# Altium schematic sheet BW1098FFC_TopLevel.SchDoc(BW1098FFC_TopLevel)
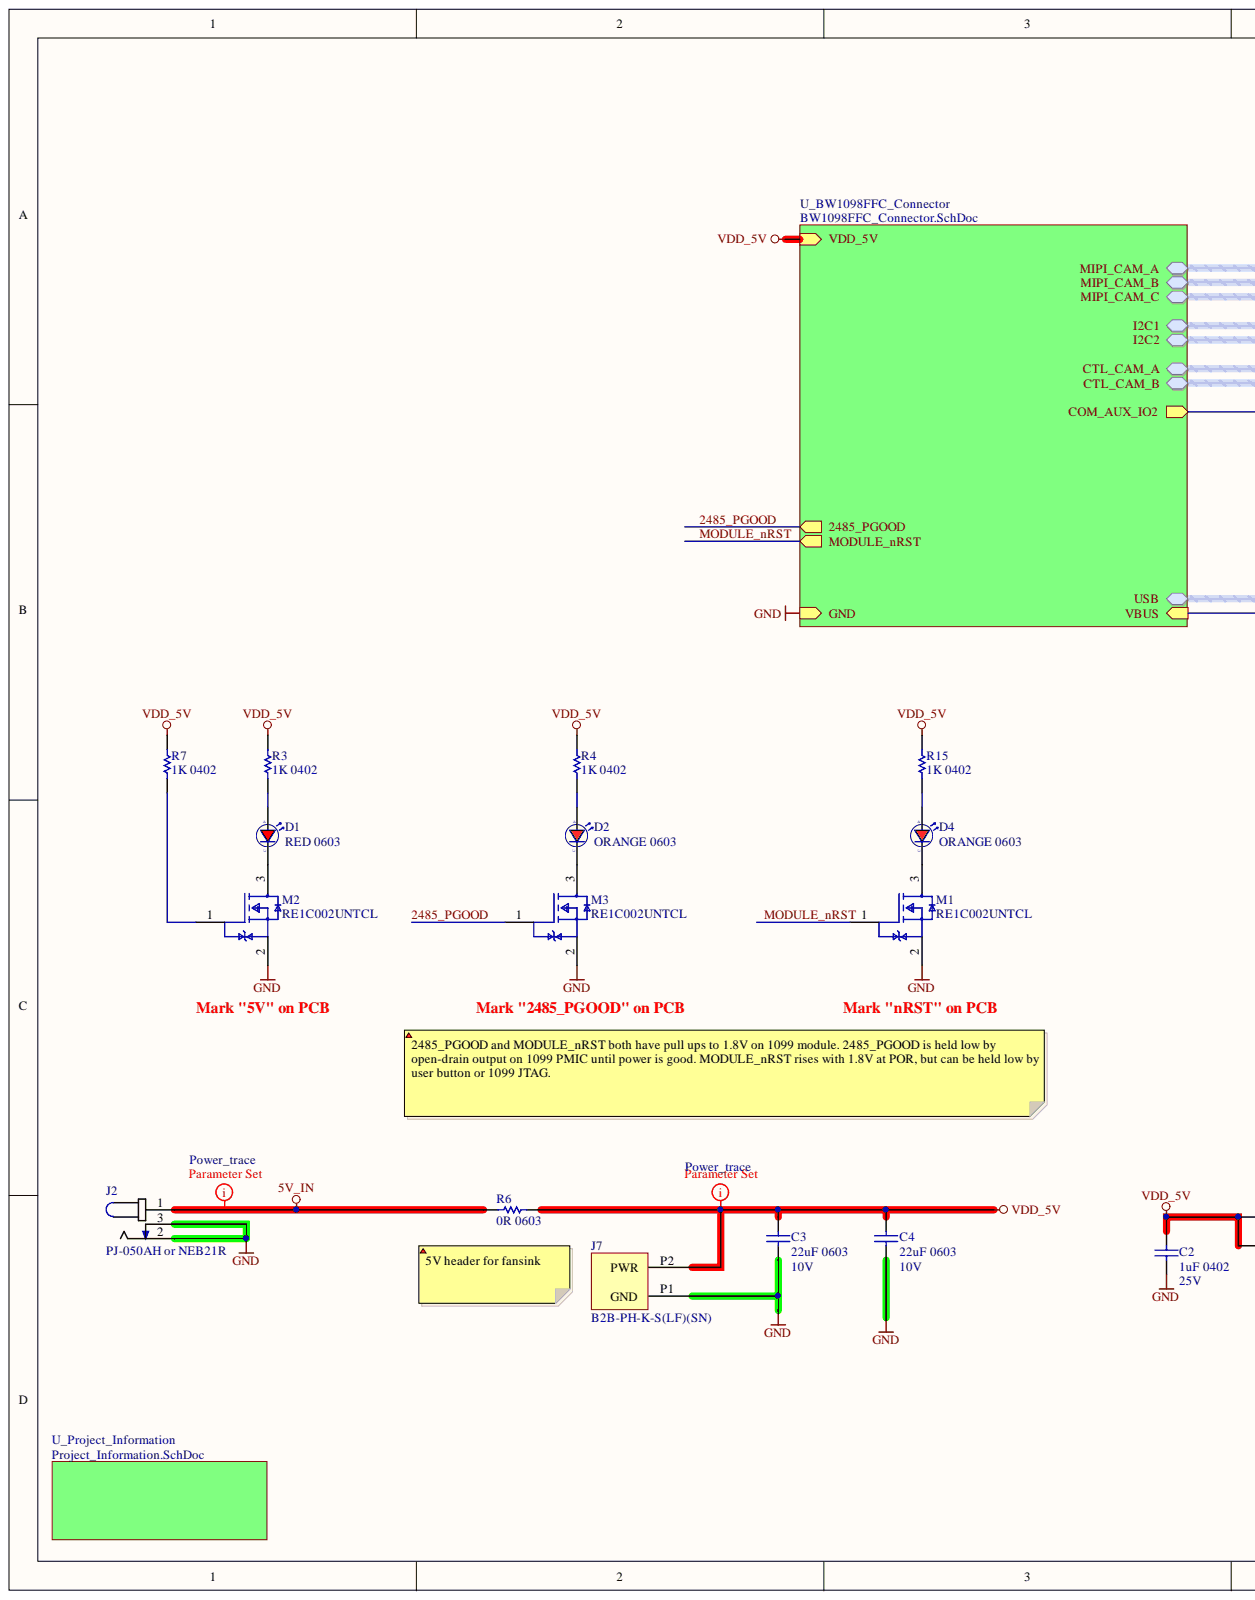
[diagram p0: BW1098FFC_TopLevel.SchDoc, left]
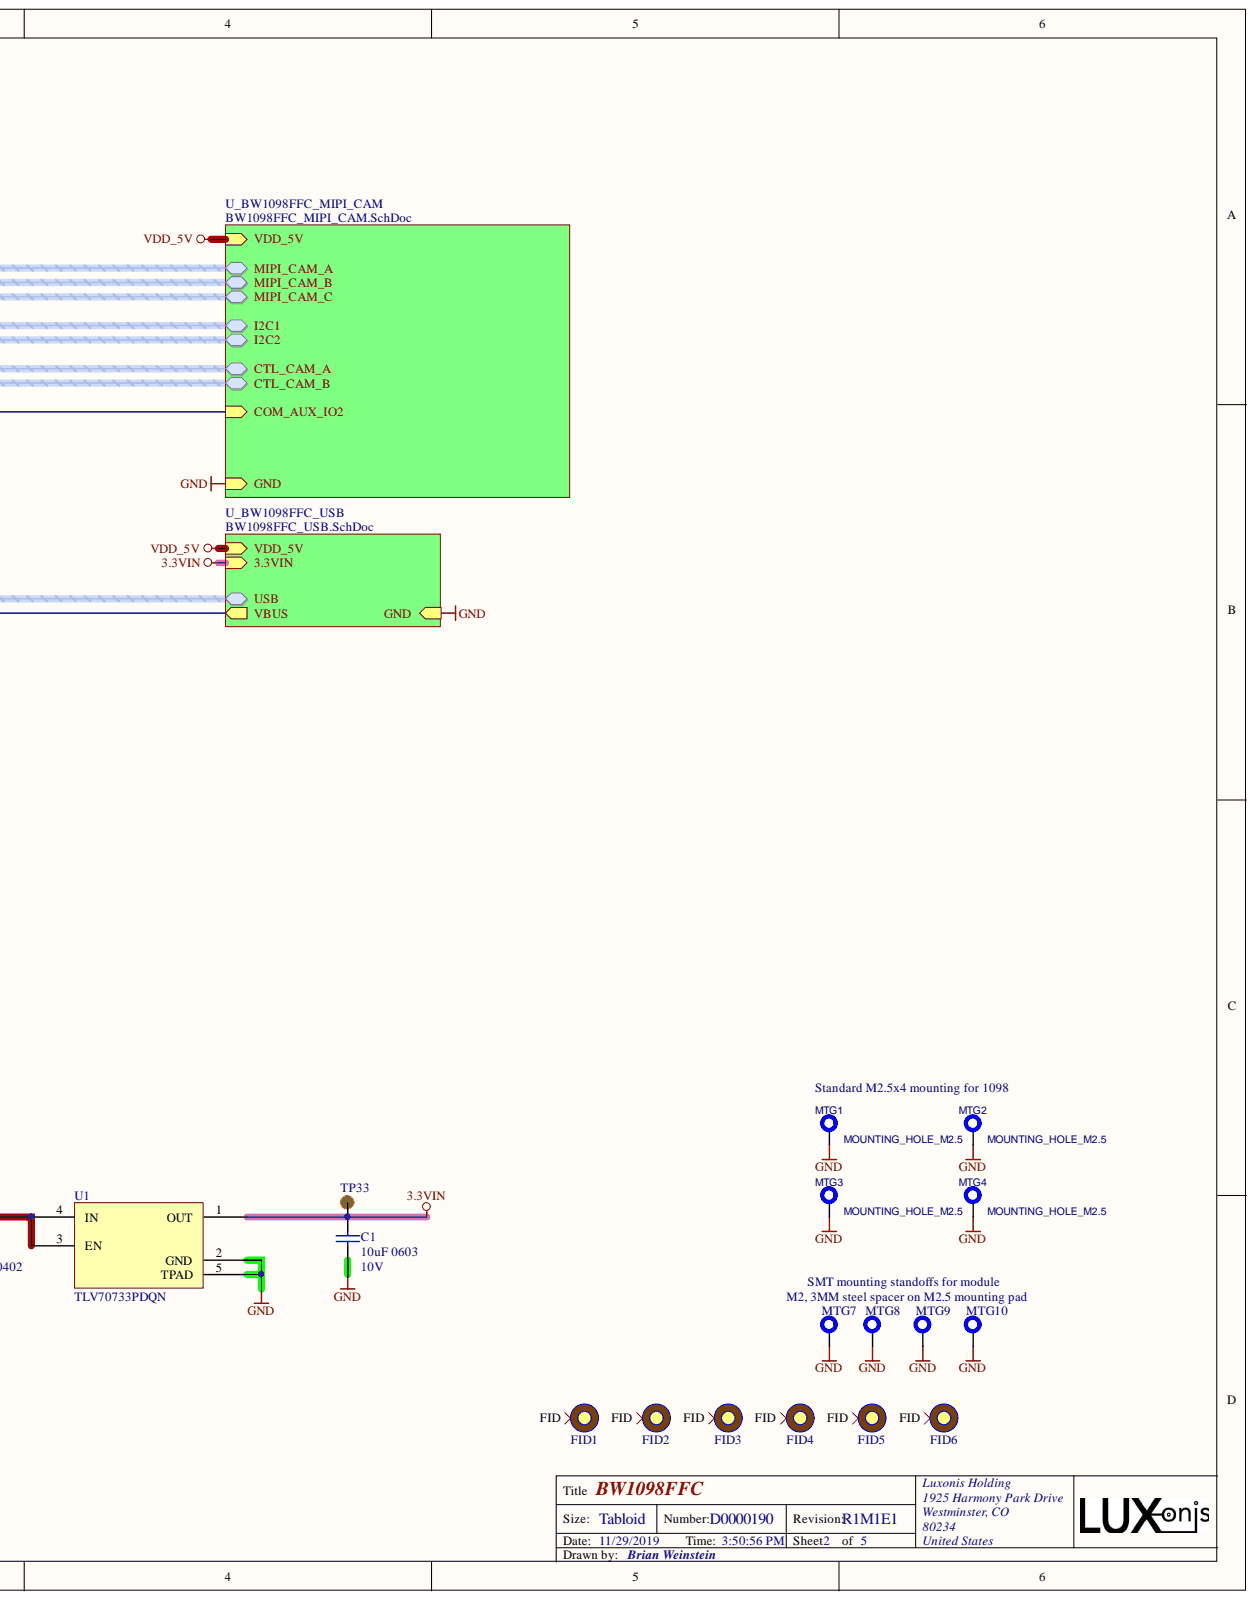
[diagram p1: BW1098FFC_TopLevel.SchDoc, right]

## Parts
C1  10uF 0603  Voltage Rating (DC): 10V  Case Code (Imperial): 0603  Capacitance: 10uF  [CAPC0603(1608)100_N]
C2  1uF 0402  Voltage Rating (DC): 25V  Capacitance: 1uF  [CAPC0402(1005)70_N]
C3  22uF 0603  Voltage Rating (DC): 10V  Case Code (Imperial): 0603  Capacitance: 22uF  [CAPC0603(1608)100_N]
C4  22uF 0603  Voltage Rating (DC): 10V  Case Code (Imperial): 0603  Capacitance: 22uF  [CAPC0603(1608)100_N]
D1  RED 0603  Case Code (Imperial): 0603  [SML_D1xx8W]
D2  ORANGE 0603  [SML_D1xx8W]
D4  ORANGE 0603  [SML_D1xx8W]
FID1  FIDUCIAL_ROUND_1MM  [FIDUCIAL_ROUND_1MM]  (value not drawn)  (no BOM)
FID2  FIDUCIAL_ROUND_1MM  [FIDUCIAL_ROUND_1MM]  (value not drawn)  (no BOM)
FID3  FIDUCIAL_ROUND_1MM  [FIDUCIAL_ROUND_1MM]  (value not drawn)  (no BOM)
FID4  FIDUCIAL_ROUND_1MM  [FIDUCIAL_ROUND_1MM]  (value not drawn)  (no BOM)
FID5  FIDUCIAL_ROUND_1MM  [FIDUCIAL_ROUND_1MM]  (value not drawn)  (no BOM)
FID6  FIDUCIAL_ROUND_1MM  [FIDUCIAL_ROUND_1MM]  (value not drawn)  (no BOM)
J2  PJ-050AH or NEB21R  Manufacturer Part Number 1: PJ-050AH  [PJ-050AH/NEB21R_COMBO]
J7  B2B-PH-K-S(LF)(SN)  [B2B-PH-K-S(LF)(SN)]
M1  RE1C002UNTCL  [RE1C002UNTCL]
M2  RE1C002UNTCL  [RE1C002UNTCL]
M3  RE1C002UNTCL  [RE1C002UNTCL]
MTG1  MOUNTING_HOLE_M2.5  [MOUNTING_HOLE_M2.5]  (no BOM)
MTG2  MOUNTING_HOLE_M2.5  [MOUNTING_HOLE_M2.5]  (no BOM)
MTG3  MOUNTING_HOLE_M2.5  [MOUNTING_HOLE_M2.5]  (no BOM)
MTG4  MOUNTING_HOLE_M2.5  [MOUNTING_HOLE_M2.5]  (no BOM)
MTG7  M2, 3MM steel spacer on M2.5 mounting pad  [9774030243R w/ bottom pad]
MTG8  M2, 3MM steel spacer on M2.5 mounting pad  [9774030243R w/ bottom pad]
MTG9  M2, 3MM steel spacer on M2.5 mounting pad  [9774030243R w/ bottom pad]
MTG10  M2, 3MM steel spacer on M2.5 mounting pad  [9774030243R w/ bottom pad]
R3  1K 0402  Case Code (Imperial): 0402  [RESC0402(1005)_N]
R4  1K 0402  Case Code (Imperial): 0402  [RESC0402(1005)_N]
R6  0R 0603  Case Code (Imperial): 0603  [RESC0603(1608)_N]
R7  1K 0402  Case Code (Imperial): 0402  [RESC0402(1005)_N]
R15  1K 0402  Case Code (Imperial): 0402  [RESC0402(1005)_N]
TP33  TP100_200_NS  [TP100_200_NS]  (value not drawn)  (no BOM)
U1  TLV70733PDQN  [TLV70733PDQN]

## Nets
3.3VIN: C1.2 TP33.1 U1.1(OUT)
5V_IN: J2.1 R6.1
GND: C1.1 C2.1 C3.1 C4.1 J2.2 J2.3 J7.P1 M1.2 M2.2 M3.2 MTG1.1 MTG2.1 MTG3.1 MTG4.1 MTG7.1 MTG8.1 MTG9.1 MTG10.1 U1.2(GND) U1.5(TPAD)
VDD_5V: C2.2 C3.2 C4.2 J7.P2 R3.2 R4.2 R6.2 R7.2 R15.2 U1.3(EN) U1.4(IN)
2485_PGOOD: M3.1
MODULE_nRST: M1.1
NetD1_1: D1.1 M2.3
NetD1_2: D1.2 R3.1
NetD2_1: D2.1 M3.3
NetD2_2: D2.2 R4.1
NetD4_1: D4.1 M1.3
NetD4_2: D4.2 R15.1
NetFID1_FID: FID1.FID
NetFID2_FID: FID2.FID
NetFID3_FID: FID3.FID
NetFID4_FID: FID4.FID
NetFID5_FID: FID5.FID
NetFID6_FID: FID6.FID
NetM2_1: M2.1 R7.1
COM_AUX_IO2:
CTL_CAM_A.CAM_A_CLK_OUT:
CTL_CAM_A.CAM_A_PWDN_N:
CTL_CAM_B.CAM_B_CLK_OUT:
CTL_CAM_B.CAM_B_D_PWM:
CTL_CAM_B.CAM_B_PWDN_N:
I2C1.I2C1_SCL:
I2C1.I2C1_SDA:
I2C2.I2C2_SCL:
I2C2.I2C2_SDA:
MIPI_CAM_A.MIPI_RX4_C_N:
MIPI_CAM_A.MIPI_RX4_C_P:
MIPI_CAM_A.MIPI_RX4_D0_N:
MIPI_CAM_A.MIPI_RX4_D0_P:
MIPI_CAM_A.MIPI_RX4_D1_N:
MIPI_CAM_A.MIPI_RX4_D1_P:
MIPI_CAM_A.MIPI_RX5_D0_N:
MIPI_CAM_A.MIPI_RX5_D0_P:
MIPI_CAM_A.MIPI_RX5_D1_N:
MIPI_CAM_A.MIPI_RX5_D1_P:
MIPI_CAM_B.MIPI_RX2_C_N:
MIPI_CAM_B.MIPI_RX2_C_P:
MIPI_CAM_B.MIPI_RX2_D0_N:
MIPI_CAM_B.MIPI_RX2_D0_P:
MIPI_CAM_B.MIPI_RX2_D1_N:
MIPI_CAM_B.MIPI_RX2_D1_P:
MIPI_CAM_C.MIPI_RX3_C_N:
MIPI_CAM_C.MIPI_RX3_C_P:
MIPI_CAM_C.MIPI_RX3_D0_N:
MIPI_CAM_C.MIPI_RX3_D0_P:
MIPI_CAM_C.MIPI_RX3_D1_N:
MIPI_CAM_C.MIPI_RX3_D1_P:
USB.USB_D_N:
USB.USB_D_P:
USB.USB_RX_N:
USB.USB_RX_P:
USB.USB_TX_N:
USB.USB_TX_P:
VBUS:

## Library data of the parts
C1 = GRM188R61A106ME69D: CAP CER 10UF 10V X5R 0603 20%; Manufacturer 1: Murata; Material: Ceramic; Width: 0.8mm; Weight: 0.000222oz; Voltage Rating: 10V; Tolerance: 20%; Thickness: 0.035inch; Termination: SMD/SMT; Supplier Part Number 1: 490-10475-1-ND; Supplier 1: Digi-Key; Stock 1: 2873098; RoHS: Yes; REACH SVHC: Unknown; Pricing 1: 1=0.21000, 10=0.14100, 100=0.07130, 500=0.04876, 1000=0.04313 (USD); Packaging: Tape and Reel; Package Quantity: 4000; Mount: Surface Mount; Min Operating Temperature: -55°C; Max Operating Temperature: 85°C; Manufacturer Part Number 1: GRM188R61A106ME69D; Length: 1.6mm; Lead Free: Lead Free; Height: 0.8mm; Dielectric: X5R; Depth: 0.8mm; ComponentLink8URL: http://www.farnell.com/datasheets/2048014.pdf?src-supplier=Newark; ComponentLink8Description: http://www.farnell.com/datasheets/2048014.pdf?src-supplier=Newark; ComponentLink7URL: http://www.farnell.com/datasheets/2048014.pdf?src-supplier=Element14; ComponentLink7Description: http://www.farnell.com/datasheets/2048014.pdf?src-supplier=Element14; ComponentLink6URL: http://www.farnell.com/datasheets/1872210.pdf?src-supplier=Newark; ComponentLink6Description: http://www.farnell.com/datasheets/1872210.pdf?src-supplier=Newark; ComponentLink5URL: http://search.murata.co.jp/Ceramy/image/img/A01X/G101/ENG/GRM188R61A106ME69-01.pdf?src-supplier=Digi-Key; ComponentLink5Description: http://search.murata.co.jp/Ceramy/image/img/A01X/G101/ENG/GRM188R61A106ME69-01.pdf?src-supplier=Digi-Key; ComponentLink4URL: http://www.farnell.com/datasheets/1872210.pdf?src-supplier=Farnell; ComponentLink4Description: http://www.farnell.com/datasheets/1872210.pdf?src-supplier=Farnell; ComponentLink3URL: http://www.farnell.com/datasheets/2048014.pdf?src-supplier=Farnell; ComponentLink3Description: http://www.farnell.com/datasheets/2048014.pdf?src-supplier=Farnell; ComponentLink2URL: http://components.arrow.com/part/dataview/0323_06119472?src-supplier=Arrow+Europe; ComponentLink2Description: http://components.arrow.com/part/dataview/0323_06119472?src-supplier=Arrow+Europe; ComponentLink1URL: http://www.mouser.com/ds/2/281/c02e-2905.pdf?src-supplier=Mouser; ComponentLink1Description: http://www.mouser.com/ds/2/281/c02e-2905.pdf?src-supplier=Mouser; Component Kind: Standard; Case Code (Metric): 1608; Case/Package: 0603; Library Name: LuxonisMaster.SchLib
C2 = GRM155R61E105KA12D: CAP CER 1UF 25V X5R 0402 10%; Manufacturer 1: Murata; Material: Ceramic; Width: 0.5mm; Weight: 0.000212oz; Voltage Rating: 25V; Voltage: 25V; Tolerance: 10%; Thickness: 0.022inch; Termination: SMD/SMT; Supplier Part Number 1: 490-10017-1-ND; Supplier 1: Digi-Key; Stock 1: 200653; RoHS: Yes; REACH SVHC: No SVHC; Pricing 1: 1=0.10000, 10=0.07100, 100=0.03350, 500=0.02352, 1000=0.01947, 2500=0.01812, 5000=0.01658 (USD); Packaging: Tape and Reel; Package Quantity: 10000; Mount: Surface Mount; Min Operating Temperature: -55°C; Max Operating Temperature: 85°C; Manufacturer Part Number 1: GRM155R61E105KA12D; Length: 1mm; Lead Free: Lead Free; Height: 0.5mm; Dielectric: X5R; Depth: 0.5mm; ComponentLink2URL: https://datasheet.ciiva.com/7719/1666664-7719006.pdf?src-supplier=Element14; ComponentLink2Description: https://datasheet.ciiva.com/7719/1666664-7719006.pdf?src-supplier=Element14; ComponentLink1URL: https://datasheet.ciiva.com/22732/1666664-22732122.pdf?src-supplier=Element14; ComponentLink1Description: https://datasheet.ciiva.com/22732/1666664-22732122.pdf?src-supplier=Element14; Component Kind: Standard; Case Code (Metric): 1005; Case Code (Imperial): 0402; Case/Package: 0402; Library Name: LuxonisMaster.SchLib
C3 C4 = GRM188R61A226ME15D: CAP CER 22UF 10V X5R 0603 20%; Manufacturer 1: Murata; Material: Ceramic; Width: 0.8mm; Weight: 0.000222oz; Voltage Rating: 10V; Tolerance: 20%; Thickness: 0.039inch; Termination: SMD/SMT; Supplier Part Number 1: 490-10476-1-ND; Supplier 1: Digi-Key; Stock 1: 984716; RoHS: Yes; REACH SVHC: No SVHC; Pricing 1: 1=0.31000, 10=0.21500, 100=0.12160, 500=0.08584, 1000=0.07512 (USD); Packaging: Tape and Reel; Package Quantity: 4000; Mount: Surface Mount; Min Operating Temperature: -55°C; Max Operating Temperature: 85°C; Manufacturer Part Number 1: GRM188R61A226ME15D; Length: 1.6mm; Lead Free: Lead Free; Height: 0.8mm; Dielectric: X5R; Depth: 0.8mm; ComponentLink1URL: https://datasheet.ciiva.com/46444/1769893-46444975.pdf?src-supplier=Element14; ComponentLink1Description: https://datasheet.ciiva.com/46444/1769893-46444975.pdf?src-supplier=Element14; Component Kind: Standard; Case Code (Metric): 1608; Case/Package: 0603; Library Name: LuxonisMaster.SchLib
D1 = SML-D12U8WT86: LED RED DIFFUSED 0603 SMD Red 620nm LED Indication - Discrete 2.2V 0603 (1608 Metric); Manufacturer 1: Rohm; Viewing Angle: 160°; Test Current: 20mA; Number of LEDs: 1; Luminous Intensity: 63mcd; Lens Style: Rectangular,Diffused,Colorless; Lens Color: White,Clear,Diffused; Width: 0.8mm; Wavelength: 620nm; Supplier Part Number 1: 511-1580-1-ND; Supplier 1: Digi-Key; Stock 1: 63748; RoHS: Yes; REACH SVHC: No SVHC; Radiation Hardening: No; Pricing 1: 1=0.33000, 10=0.23900, 100=0.11340, 500=0.07560, 1000=0.05922 (USD); Packaging: Tape and Reel; Package Quantity: 1; Number of Pins: 2; Mount: Surface Mount; Min Operating Temperature: -40°C; Max Operating Temperature: 85°C; Manufacturer Part Number 1: SML-D12U8WT86; Lens Transparency: Diffused; Length: 1.6mm; Lead Free: Lead Free; Illumination Color: Red; Height: 0.65mm; Forward Voltage: 2.2V; Forward Current: 20mA; Dominant Wavelength: 620nm; ComponentLink6URL: https://datasheet.ciiva.com/17420/sml-d1-17420939.pdf?src-supplier=Avnet+Europe; ComponentLink6Description: https://datasheet.ciiva.com/17420/sml-d1-17420939.pdf?src-supplier=Avnet+Europe; ComponentLink5URL: https://datasheet.ciiva.com/9324/sml-d1-9324826.pdf?src-supplier=Avnet; ComponentLink5Description: https://datasheet.ciiva.com/9324/sml-d1-9324826.pdf?src-supplier=Avnet; ComponentLink4URL: https://datasheet.ciiva.com/2110/sml-d1-2110377.pdf?src-supplier=Digi-Key; ComponentLink4Description: https://datasheet.ciiva.com/2110/sml-d1-2110377.pdf?src-supplier=Digi-Key; ComponentLink3URL: https://datasheet.ciiva.com/2110/sml-d1-2110376.pdf?src-supplier=Digi-Key; ComponentLink3Description: https://datasheet.ciiva.com/2110/sml-d1-2110376.pdf?src-supplier=Digi-Key; ComponentLink2URL: https://datasheet.ciiva.com/2110/sml-d1-2110375.pdf?src-supplier=Digi-Key; ComponentLink2Description: https://datasheet.ciiva.com/2110/sml-d1-2110375.pdf?src-supplier=Digi-Key; ComponentLink1URL: https://datasheet.ciiva.com/11774/0900766b80df3ada-11774020.pdf?src-supplier=RSComponents; ComponentLink1Description: https://datasheet.ciiva.com/11774/0900766b80df3ada-11774020.pdf?src-supplier=RSComponents; Component Kind: Standard; Color: Red; Case Code (Metric): 1608; Case/Package: 0603; Library Name: LuxonisMaster.SchLib
D2 D4 = SML-D12D8WT86: LED ORANGE DIFFUSED 0603 SMD Orange 605nm LED Indication - Discrete 2.2V 0603 (1608 Metric); Manufacturer 1: Rohm; Viewing Angle: 160°; Test Current: 20mA; Number of LEDs: 1; Luminous Intensity: 100mcd; Lens Style: Diffused,Colorless,Rectangular; Lens Color: White; Width: 0.8mm; Wavelength: 605nm; Supplier Part Number 1: 511-1577-1-ND; Supplier 1: Digi-Key; Stock 1: 29355; RoHS: Yes; REACH SVHC: Unknown; Radiation Hardening: No; Pricing 1: 1=0.33000, 10=0.23900, 100=0.11340, 500=0.07560, 1000=0.05922 (USD); Packaging: Tape and Reel; Package Quantity: 1; Number of Pins: 2; Mount: Surface Mount; Min Operating Temperature: -40°C; Max Operating Temperature: 85°C; Manufacturer Part Number 1: SML-D12D8WT86; Lens Transparency: Diffused; Length: 1.6mm; Lead Free: Lead Free; Illumination Color: Orange; Height: 0.65mm; Forward Voltage: 2.2V; Forward Current: 20mA; Dominant Wavelength: 605nm; ComponentLink6URL: https://datasheet.ciiva.com/17419/sml-d1-17419886.pdf?src-supplier=Avnet+Europe; ComponentLink6Description: https://datasheet.ciiva.com/17419/sml-d1-17419886.pdf?src-supplier=Avnet+Europe; ComponentLink5URL: https://datasheet.ciiva.com/2115/sml-d1-2115579.pdf?src-supplier=Digi-Key; ComponentLink5Description: https://datasheet.ciiva.com/2115/sml-d1-2115579.pdf?src-supplier=Digi-Key; ComponentLink4URL: https://datasheet.ciiva.com/16387/sml-d1-16387850.pdf?src-supplier=Future+Electronics; ComponentLink4Description: https://datasheet.ciiva.com/16387/sml-d1-16387850.pdf?src-supplier=Future+Electronics; ComponentLink3URL: https://datasheet.ciiva.com/3161/sml-d1-3161418.pdf?src-supplier=Avnet; ComponentLink3Description: https://datasheet.ciiva.com/3161/sml-d1-3161418.pdf?src-supplier=Avnet; ComponentLink2URL: https://datasheet.ciiva.com/2115/sml-d1-2115578.pdf?src-supplier=Digi-Key; ComponentLink2Description: https://datasheet.ciiva.com/2115/sml-d1-2115578.pdf?src-supplier=Digi-Key; ComponentLink1URL: https://datasheet.ciiva.com/2115/sml-d1-2115577.pdf?src-supplier=Digi-Key; ComponentLink1Description: https://datasheet.ciiva.com/2115/sml-d1-2115577.pdf?src-supplier=Digi-Key; Component Kind: Standard; Color: Orange; Case Code (Metric): 1608; Case Code (Imperial): 0603; Case/Package: 0603; Library Name: LuxonisMaster.SchLib
FID1-FID6 = FIDUCIAL: Fiducial with various available footprints; Library Name: LuxonisMaster.SchLib
J2 = PJ-050AH/NEB21R_COMBO: CONN POWER PIN 2 POS RA PTH Barrel jack 2.1mm x 5.5mm combo footprint; Manufacturer 1: CUI; Voltage Rating (DC): 12V; Voltage Rating: 24V; Shielding: Unshielded; Number of Ports: 1; Number of Conductors: 2; Max Current Rating: 3A; Insulation Resistance: 100MR; Inside Diameter: 6mm; Gender: Male; Current Rating: 5A; Voltage Rating (AC): 12V; Termination: Solder; Supplier Part Number 2: 97K6458; Supplier Part Number 1: 490-PJ-050AH; Supplier 2: Newark; Supplier 1: Mouser; Stock 2: 56; Stock 1: 2665; REACH SVHC: No SVHC; Pricing 2: 1=0.86400, 25=0.82100, 50=0.78300, 100=0.72900, 250=0.68600 (USD); Pricing 1: 1=0.78000, 10=0.68800, 50=0.62100, 100=0.59400, 1000=0.43200, 10000=0.39100 (USD); Packaging: Bulk; Package Quantity: 500; Orientation: Horizontal; Number of Terminals: 3; Number of Pins: 2; Mount: Through Hole; Min Operating Temperature: -25°C; Max Voltage Rating (DC): 12V; Max Operating Temperature: 85°C; Manufacturer Part Number 2: NEB 21 R; Manufacturer 2: Lumberg; Length: 9mm; Lead Free: Lead Free; Housing Material: Thermoplastic; Housing Color: Black; Flammability Rating: UL94 V-0; Depth: 14.5mm; Contact Plating: Nickel; Contact Material: Copper; Contact Gender: Male; Connector Type: Jack; ComponentLink17URL: http://datasheet.octopart.com/NEB-21-R-Lumberg-datasheet-136395310.pdf?src-supplier=Toby+Electronics; ComponentLink17Description: http://datasheet.octopart.com/NEB-21-R-Lumberg-datasheet-136395310.pdf?src-supplier=Toby+Electronics; ComponentLink16URL: http://datasheet.octopart.com/NEB-21-R-Lumberg-datasheet-103789672.pdf?src-supplier=Farnell; ComponentLink16Description: http://datasheet.octopart.com/NEB-21-R-Lumberg-datasheet-103789672.pdf?src-supplier=Farnell; ComponentLink15URL: http://datasheet.octopart.com/NEB-21-R-Lumberg-datasheet-15206778.pdf?src-supplier=Newark; ComponentLink15Description: http://datasheet.octopart.com/NEB-21-R-Lumberg-datasheet-15206778.pdf?src-supplier=Newark; ComponentLink14URL: https://datasheet.ciiva.com/29365/738882-da-01-ml-netzger-einbaukuppl-neb-21-r-de-en-fr-29365351.pdf?src-supplier=Conrad; ComponentLink14Description: https://datasheet.ciiva.com/29365/738882-da-01-ml-netzger-einbaukuppl-neb-21-r-de-en-fr-29365351.pdf?src-supplier=Conrad; ComponentLink13URL: https://datasheet.ciiva.com/8066/1700582-8066298.pdf?src-supplier=Element14; ComponentLink13Description: https://datasheet.ciiva.com/8066/1700582-8066298.pdf?src-supplier=Element14; ComponentLink12URL: https://datasheet.ciiva.com/1115/28897-1115898.pdf?src-supplier=Newark; ComponentLink12Description: https://datasheet.ciiva.com/1115/28897-1115898.pdf?src-supplier=Newark; ComponentLink11URL: https://datasheet.ciiva.com/11720/0900766b805694d6-11720273.pdf?src-supplier=RSComponents; ComponentLink11Description: https://datasheet.ciiva.com/11720/0900766b805694d6-11720273.pdf?src-supplier=RSComponents; ComponentLink10URL: http://datasheet.octopart.com/PJ-050AH-CUI-datasheet-102985732.pdf?src-supplier=Future+Electronics; ComponentLink10Description: http://datasheet.octopart.com/PJ-050AH-CUI-datasheet-102985732.pdf?src-supplier=Future+Electronics; ComponentLink9URL: http://datasheet.octopart.com/PJ-050AH-CUI-datasheet-62073522.pdf?src-supplier=Future+Electronics; ComponentLink9Description: http://datasheet.octopart.com/PJ-050AH-CUI-datasheet-62073522.pdf?src-supplier=Future+Electronics; ComponentLink8URL: http://datasheet.octopart.com/PJ-050AH-CUI-datasheet-34390405.pdf?src-supplier=Future+Electronics; ComponentLink8Description: http://datasheet.octopart.com/PJ-050AH-CUI-datasheet-34390405.pdf?src-supplier=Future+Electronics; ComponentLink7URL: http://datasheet.octopart.com/PJ-050AH-CUI-datasheet-103111417.pdf?src-supplier=Electro+Sonic; ComponentLink7Description: http://datasheet.octopart.com/PJ-050AH-CUI-datasheet-103111417.pdf?src-supplier=Electro+Sonic; ComponentLink6URL: http://datasheet.octopart.com/PJ-050AH-CUI-datasheet-103766550.pdf?src-supplier=CUI; ComponentLink6Description: http://datasheet.octopart.com/PJ-050AH-CUI-datasheet-103766550.pdf?src-supplier=CUI; ComponentLink5URL: http://datasheet.octopart.com/PJ-050AH-CUI-datasheet-65972796.pdf?src-supplier=CUI; ComponentLink5Description: http://datasheet.octopart.com/PJ-050AH-CUI-datasheet-65972796.pdf?src-supplier=CUI; ComponentLink4URL: http://datasheet.octopart.com/PJ-050AH-CUI-datasheet-62089837.pdf?src-supplier=CUI; ComponentLink4Description: http://datasheet.octopart.com/PJ-050AH-CUI-datasheet-62089837.pdf?src-supplier=CUI; ComponentLink3URL: http://datasheet.octopart.com/PJ-050AH-CUI-datasheet-15696426.pdf?src-supplier=CUI; ComponentLink3Description: http://datasheet.octopart.com/PJ-050AH-CUI-datasheet-15696426.pdf?src-supplier=CUI; ComponentLink2URL: https://www.cui.com/product/resource/digikeypdf/pj-050ah.pdf?src-supplier=Digi-Key; ComponentLink2Description: https://www.cui.com/product/resource/digikeypdf/pj-050ah.pdf?src-supplier=Digi-Key; ComponentLink1URL: http://www.mouser.com/ds/2/670/PJ-050AH-524150.pdf?src-supplier=Mouser; ComponentLink1Description: http://www.mouser.com/ds/2/670/PJ-050AH-524150.pdf?src-supplier=Mouser; Component Kind: Standard; Color: Black; Library Name: LuxonisMaster.SchLib
J7 = B2B-PH-K-S(LF)(SN): CONN HEADER VERT 2POS 2MM; Manufacturer 1: JST; Working Voltage: 100V; Number of Positions: 2; Max Current Rating: 2A; Insulation Resistance: 1MR; Gender: Male; Contact Resistance: 20mR; Connector Type: Male Pin; Width: 4.5mm; Voltage Rating (AC): 100V; Voltage Rating: 100V; Termination: Solder; Supplier Part Number 1: 455-1704-ND; Supplier 1: Digi-Key; Stock 1: 216199; RoHS: Yes; REACH SVHC: No SVHC; Pricing 1: 1 = 0.170000, 10 = 0.162000, 100 = 0.100200, 500 = 0.082880, 1000 = 0.072520, 5000 = 0.062160, 10000 = 0.060440 (USD); Pitch: 2mm; Packaging: Bulk; Orientation: Straight; Number of Rows: 1; Number of Poles: 2; Number of Pins: 2; Number of Contacts: 2; Mount: Through Hole; Min Operating Temperature: -25°C; Max Voltage Rating (DC): 100V; Max Voltage Rating (AC): 100V; Max Operating Temperature: 85°C; Manufacturer Part Number 1: B2B-PH-K-S(LF)(SN); Length: 5.9mm; Lead Pitch: 2mm; Lead Free: Lead Free; Housing Material: Polyamide; Housing Color: White; Height: 9.4mm; Fastening Type: Detent; Depth: 4.5mm; Current Rating: 2A; Contact Plating: Tin; Contact Mating Length: 0.13inch; Contact Material: Brass; ComponentLink5URL: https://datasheet.ciiva.com/16422/b2b-ph-k-slfsn-16422715.pdf?src-supplier=Future+Electronics; ComponentLink5Description: https://datasheet.ciiva.com/16422/b2b-ph-k-slfsn-16422715.pdf?src-supplier=Future+Electronics; ComponentLink4URL: https://datasheet.ciiva.com/712/eph-712442.pdf?src-supplier=Digi-Key; ComponentLink4Description: https://datasheet.ciiva.com/712/eph-712442.pdf?src-supplier=Digi-Key; ComponentLink3URL: https://datasheet.ciiva.com/8052/80560-8052022.pdf?src-supplier=Element14; ComponentLink3Description: https://datasheet.ciiva.com/8052/80560-8052022.pdf?src-supplier=Element14; ComponentLink2URL: https://datasheet.ciiva.com/11746/0900766b8082f551-11746676.pdf?src-supplier=RSComponents; ComponentLink2Description: https://datasheet.ciiva.com/11746/0900766b8082f551-11746676.pdf?src-supplier=RSComponents; ComponentLink1URL: https://datasheet.ciiva.com/6747/80560-6747392.pdf?src-supplier=Newark; ComponentLink1Description: https://datasheet.ciiva.com/6747/80560-6747392.pdf?src-supplier=Newark; Component Kind: Standard; Color: Natural; Library Name: LuxonisMaster.SchLib
M1-M3 = RE1C002UNTCL: MOSFET N-CH 20V 0.2A EMT3; Manufacturer 1: Rohm; Rds On Max: 1.2R; Max Power Dissipation: 150mW; Input Capacitance: 25pF; Continuous Drain Current (ID): 200mA; Supplier Part Number 1: RE1C002UNTCLCT-ND; Supplier 1: Digi-Key; Stock 1: 868886; RoHS: Yes; Pricing 1: 1=0.20000, 10=0.18200, 100=0.09930, 500=0.06112, 1000=0.04167 (USD); Packaging: Tape and Reel; Mount: Surface Mount; Manufacturer Part Number 1: RE1C002UNTCL; Lead Free: Lead Free; Drain to Source Voltage (Vdss): 20V; ComponentLink7URL: http://www.farnell.com/datasheets/2704376.pdf?src-supplier=Element14; ComponentLink7Description: http://www.farnell.com/datasheets/2704376.pdf?src-supplier=Element14; ComponentLink6URL: http://www.farnell.com/datasheets/2704376.pdf?src-supplier=Farnell; ComponentLink6Description: http://www.farnell.com/datasheets/2704376.pdf?src-supplier=Farnell; ComponentLink5URL: http://www.farnell.com/datasheets/2704376.pdf?src-supplier=Newark; ComponentLink5Description: http://www.farnell.com/datasheets/2704376.pdf?src-supplier=Newark; ComponentLink4URL: https://www.rohm.com/datasheet/RE1C002UN/re1c002untcl-e?src-supplier=Digi-Key; ComponentLink4Description: https://www.rohm.com/datasheet/RE1C002UN/re1c002untcl-e?src-supplier=Digi-Key; ComponentLink3URL: http://rohmfs.rohm.com/en/products/databook/datasheet/discrete/transistor/mosfet/re1c002un.pdf?src-supplier=Avnet; ComponentLink3Description: http://rohmfs.rohm.com/en/products/databook/datasheet/discrete/transistor/mosfet/re1c002un.pdf?src-supplier=Avnet; ComponentLink2URL: http://rohmfs.rohm.com/en/techdata_basic/transistor/taping/EMT3_TL_taping.pdf?src-supplier=Digi-Key; ComponentLink2Description: http://rohmfs.rohm.com/en/techdata_basic/transistor/taping/EMT3_TL_taping.pdf?src-supplier=Digi-Key; ComponentLink1URL: http://www.mouser.com/ds/2/348/re1c002untcl-e-1018231.pdf?src-supplier=Mouser; ComponentLink1Description: http://www.mouser.com/ds/2/348/re1c002untcl-e-1018231.pdf?src-supplier=Mouser; Component Kind: Standard; Case/Package: SOT-89; Library Name: LuxonisMaster.SchLib
MTG1-MTG4 = (no symbol name): =CurrentFootprint; B - Value: Not a Part; A - Level: 0; Description: MOUNTING_HOLE_M2.5; Library Name: LuxonisMaster.SchLib
MTG7-MTG10 = 9774030243R: MP ROUND STANDOFF M2X0.4 STEEL 3MM; Manufacturer 1: Wurth Electronics; Threaded/Unthreaded: Threaded; Plating: Tin; Outside Diameter: 0.171inch; Supplier Part Number 1: 732-7085-1-ND; Supplier 1: Digi-Key; Stock 1: 20568; RoHS: Yes; REACH SVHC: Unknown; Pricing 1: 1=0.95000, 10=0.91800, 50=0.79500, 100=0.73900 (USD); Material: Steel; Manufacturer Part Number 1: 9774030243R; Length: 0.173inch; ComponentLink5URL: http://www.farnell.com/datasheets/1960368.pdf?src-supplier=Element14; ComponentLink5Description: http://www.farnell.com/datasheets/1960368.pdf?src-supplier=Element14; ComponentLink4URL: http://www.farnell.com/datasheets/1960368.pdf?src-supplier=Newark; ComponentLink4Description: http://www.farnell.com/datasheets/1960368.pdf?src-supplier=Newark; ComponentLink3URL: https://katalog.we-online.de/em/datasheet/9774030243R.pdf?src-supplier=Digi-Key; ComponentLink3Description: https://katalog.we-online.de/em/datasheet/9774030243R.pdf?src-supplier=Digi-Key; ComponentLink2URL: http://www.farnell.com/datasheets/1960368.pdf?src-supplier=Farnell; ComponentLink2Description: http://www.farnell.com/datasheets/1960368.pdf?src-supplier=Farnell; ComponentLink1URL: http://www.mouser.com/ds/2/445/9774030243R-611455.pdf?src-supplier=Mouser; ComponentLink1Description: http://www.mouser.com/ds/2/445/9774030243R-611455.pdf?src-supplier=Mouser; Component Kind: Standard; Library Name: LuxonisMaster.SchLib
R3 R4 R7 R15 = RC0402FR-071KL: RES SMD 1K OHM 1% 1/16W 0402 1 kOhms ±1% 0.063W, 1/16W Chip Resistor 0402 (1005 Metric) Moisture Resistant Thick Film; Manufacturer 1: Yageo; Voltage Rating (DC): 50V; Temperature Coefficient: 100ppm/°C; Resistance: 1kR; Power Rating: 62.5mW; Width: 0.5mm; Voltage Rating: 50V; Tolerance: 1%; Termination: SMD/SMT; Supplier Part Number 1: 311-1.00KLRCT-ND; Supplier 1: Digi-Key; Stock 1: 60695854; RoHS: Yes; REACH SVHC: Unknown; Pricing 1: 1=0.10000, 10=0.01600, 100=0.00670, 1000=0.00301, 2500=0.00261, 5000=0.00215 (USD); Packaging: Tape and Reel; Number of Pins: 2; Min Operating Temperature: -55°C; Military Standard: Not; Max Operating Temperature: 155°C; Manufacturer Part Number 1: RC0402FR-071KL; Length: 1mm; Lead Free: Lead Free; Height: 0.32mm; Depth: 0.5mm; Composition: Thick Film; ComponentLink7URL: https://datasheet.ciiva.com/7849/1018594-7849871.pdf?src-supplier=Element14; ComponentLink7Description: https://datasheet.ciiva.com/7849/1018594-7849871.pdf?src-supplier=Element14; ComponentLink6URL: https://datasheet.ciiva.com/7983/1018594-7983502.pdf?src-supplier=Element14; ComponentLink6Description: https://datasheet.ciiva.com/7983/1018594-7983502.pdf?src-supplier=Element14; ComponentLink5URL: https://datasheet.ciiva.com/7849/1018594-7849869.pdf?src-supplier=Element14; ComponentLink5Description: https://datasheet.ciiva.com/7849/1018594-7849869.pdf?src-supplier=Element14; ComponentLink4URL: https://datasheet.ciiva.com/22747/1018594-22747513.pdf?src-supplier=Element14; ComponentLink4Description: https://datasheet.ciiva.com/22747/1018594-22747513.pdf?src-supplier=Element14; ComponentLink3URL: https://datasheet.ciiva.com/17063/pyu-rc0402-51-rohs-l-6-r-17063193.pdf?src-supplier=Future+Electronics; ComponentLink3Description: https://datasheet.ciiva.com/17063/pyu-rc0402-51-rohs-l-6-r-17063193.pdf?src-supplier=Future+Electronics; ComponentLink2URL: https://datasheet.ciiva.com/12666/pyu-rc0402-51-rohs-l-6-r-12666741.pdf?src-supplier=Mouser; ComponentLink2Description: https://datasheet.ciiva.com/12666/pyu-rc0402-51-rohs-l-6-r-12666741.pdf?src-supplier=Mouser; ComponentLink1URL: https://datasheet.ciiva.com/11819/0900766b80c66297-11819477.pdf?src-supplier=RSComponents; ComponentLink1Description: https://datasheet.ciiva.com/11819/0900766b80c66297-11819477.pdf?src-supplier=RSComponents; Component Kind: Standard; Case Code (Metric): 1005; Case/Package: 0402; Library Name: LuxonisMaster.SchLib
R6 = CRCW06030000Z0EA: RES SMD 0 OHM JUMPER 1/10W 0603 0 Ohms Jumper 0.1W, 1/10W Chip Resistor 0603 (1608 Metric) Automotive AEC-Q200 Thick Film; Manufacturer Part Number 1: CRCW0603-0000Z0EA; Manufacturer 1: Vishay; Working Voltage: 75V; Tolerance: 5%; Temperature Coefficient: 100ppm/°C; Resistance: 0mR; Power Rating: 100mW; Current Rating: 2A; Width: 0.033inch; Weight: 7.1E-05oz; Voltage Rating (DC): 75V; Voltage Rating: 75V; Termination: SMD/SMT; Supplier Part Number 1: 541-0.0GCT-ND; Supplier 1: Digi-Key; Stock 1: 742743; RoHS: Yes; REACH SVHC: Unknown; Radiation Hardening: No; Pricing 1: 1=0.100000, 10=0.034000, 25=0.024400, 50=0.018600, 100=0.013800, 250=0.010480, 500=0.008400, 1000=0.006180 (USD); Packaging: Tape and Reel; Package Quantity: 1; Number of Terminations: 2; Mount: Surface Mount; Min Operating Temperature: -55°C; Military Standard: Not; Max Power Dissipation: 100mW; Max Operating Temperature: 155°C; Length: 1.55mm; Lead Free: Lead Free; Height: 0.02inch; Features: Automotive AEC-Q200; Depth: 0.85mm; Contact Plating: Tin,Lead; Composition: Thick Film; ComponentLink13URL: https://datasheet.ciiva.com/16721/70201119-16721450.pdf?src-supplier=Allied+Electronics; ComponentLink13Description: https://datasheet.ciiva.com/16721/70201119-16721450.pdf?src-supplier=Allied+Electronics; ComponentLink12URL: https://datasheet.ciiva.com/7841/125364-7841818.pdf?src-supplier=Element14; ComponentLink12Description: https://datasheet.ciiva.com/7841/125364-7841818.pdf?src-supplier=Element14; ComponentLink11URL: https://datasheet.ciiva.com/7841/1720486-7841817.pdf?src-supplier=Element14; ComponentLink11Description: https://datasheet.ciiva.com/7841/1720486-7841817.pdf?src-supplier=Element14; ComponentLink10URL: https://datasheet.ciiva.com/7841/1720486-7841816.pdf?src-supplier=Element14; ComponentLink10Description: https://datasheet.ciiva.com/7841/1720486-7841816.pdf?src-supplier=Element14; ComponentLink9URL: https://datasheet.ciiva.com/5544/dcrcwe3-109170-5544344.pdf?src-supplier=Mouser; ComponentLink9Description: https://datasheet.ciiva.com/5544/dcrcwe3-109170-5544344.pdf?src-supplier=Mouser; ComponentLink8URL: https://datasheet.ciiva.com/26316/fld-crcw-e3-data-en-26316615.pdf?src-supplier=Distrelec; ComponentLink8Description: https://datasheet.ciiva.com/26316/fld-crcw-e3-data-en-26316615.pdf?src-supplier=Distrelec; ComponentLink7URL: https://datasheet.ciiva.com/16725/70201119-16725175.pdf?src-supplier=Allied+Electronics; ComponentLink7Description: https://datasheet.ciiva.com/16725/70201119-16725175.pdf?src-supplier=Allied+Electronics; ComponentLink6URL: https://datasheet.ciiva.com/16199/dcrcwe3-16199063.pdf?src-supplier=Future+Electronics; ComponentLink6Description: https://datasheet.ciiva.com/16199/dcrcwe3-16199063.pdf?src-supplier=Future+Electronics; ComponentLink5URL: https://datasheet.ciiva.com/16941/dcrcw-16941302.pdf?src-supplier=Avnet+Europe; ComponentLink5Description: https://datasheet.ciiva.com/16941/dcrcw-16941302.pdf?src-supplier=Avnet+Europe; ComponentLink4URL: https://datasheet.ciiva.com/8340/dcrcwe3-8340197.pdf?src-supplier=Avnet; ComponentLink4Description: https://datasheet.ciiva.com/8340/dcrcwe3-8340197.pdf?src-supplier=Avnet; ComponentLink3URL: https://datasheet.ciiva.com/2461/dcrcwe3-2461970.pdf?src-supplier=Digi-Key; ComponentLink3Description: https://datasheet.ciiva.com/2461/dcrcwe3-2461970.pdf?src-supplier=Digi-Key; ComponentLink2URL: https://datasheet.ciiva.com/2461/dcrcwe3-2461969.pdf?src-supplier=Digi-Key; ComponentLink2Description: https://datasheet.ciiva.com/2461/dcrcwe3-2461969.pdf?src-supplier=Digi-Key; ComponentLink1URL: https://datasheet.ciiva.com/2461/dcrcwe3-2461968.pdf?src-supplier=Digi-Key; ComponentLink1Description: https://datasheet.ciiva.com/2461/dcrcwe3-2461968.pdf?src-supplier=Digi-Key; Component Kind: Standard; Case Code (Metric): 1608; Case/Package: 0603; Library Name: LuxonisMaster.SchLib
TP33 = TEST_POINT: Library Name: LuxonisMaster.SchLib
U1 = TLV70733PDQN: IC REG LINEAR 3.3V 200MA 4X2SON Linear Voltage Regulator IC  1 Output  200mA 4-X2SON (1x1); Manufacturer Part Number 1: TLV70710DQN; Manufacturer 1: Texas Instruments; Quiescent Current: 25uA; Output Voltage: 1V; Number of Regulators: 1; Min Current Limit: 240mA; Dropout Voltage: 250mV; Supplier Part Number 1: 296-28355-1-ND; Supplier 1: Digi-Key; RoHS: Yes; Packaging: Tape and Reel; Package Quantity: 3000; Output Current: 200mA; Mount: Surface Mount; Min Operating Temperature: -40°C; Max Operating Temperature: 125°C; Lead Free: Lead Free; ComponentLink2URL: http://www.ti.com/lit/ds/symlink/tlv707.pdf?src-supplier=Digi-Key; ComponentLink2Description: http://www.ti.com/lit/ds/symlink/tlv707.pdf?src-supplier=Digi-Key; ComponentLink1URL: http://www.ti.com/lit/gpn/tlv707?src-supplier=Mouser; ComponentLink1Description: http://www.ti.com/lit/gpn/tlv707?src-supplier=Mouser; Component Kind: Standard; Library Name: LuxonisMaster.SchLib
